# Altium schematic sheet Dimmer.SchDoc
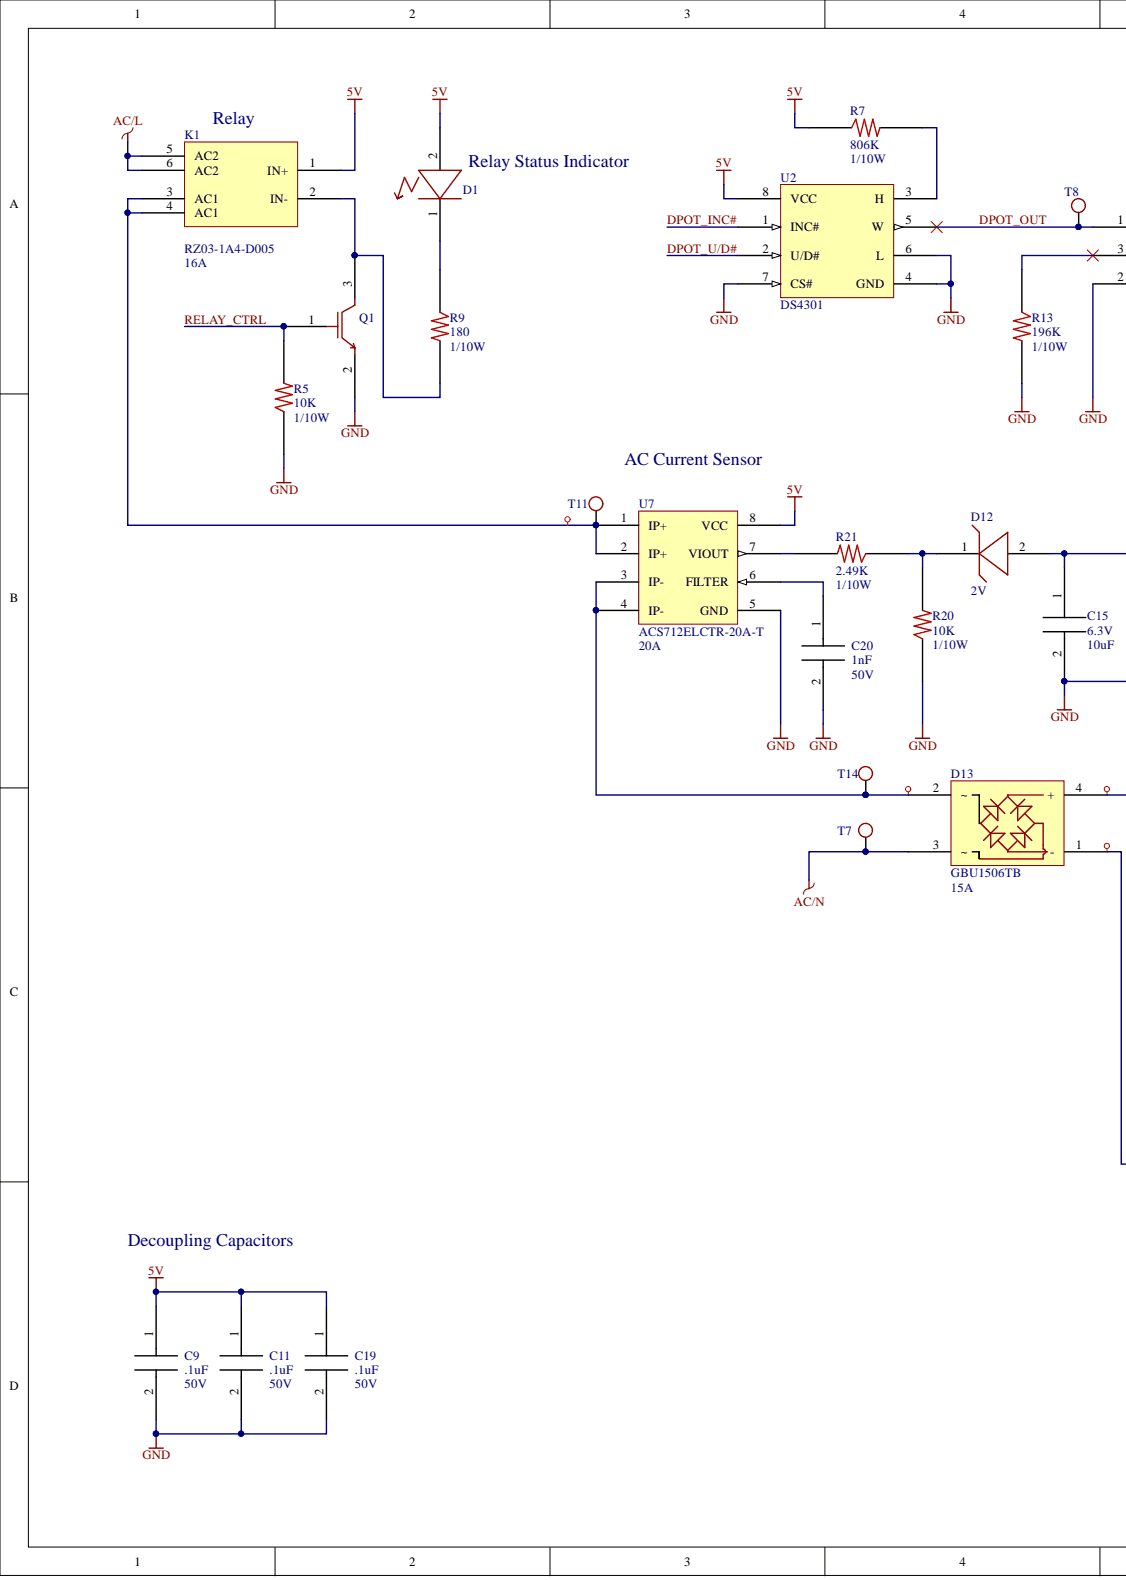
[diagram p0: Dimmer.SchDoc, left]
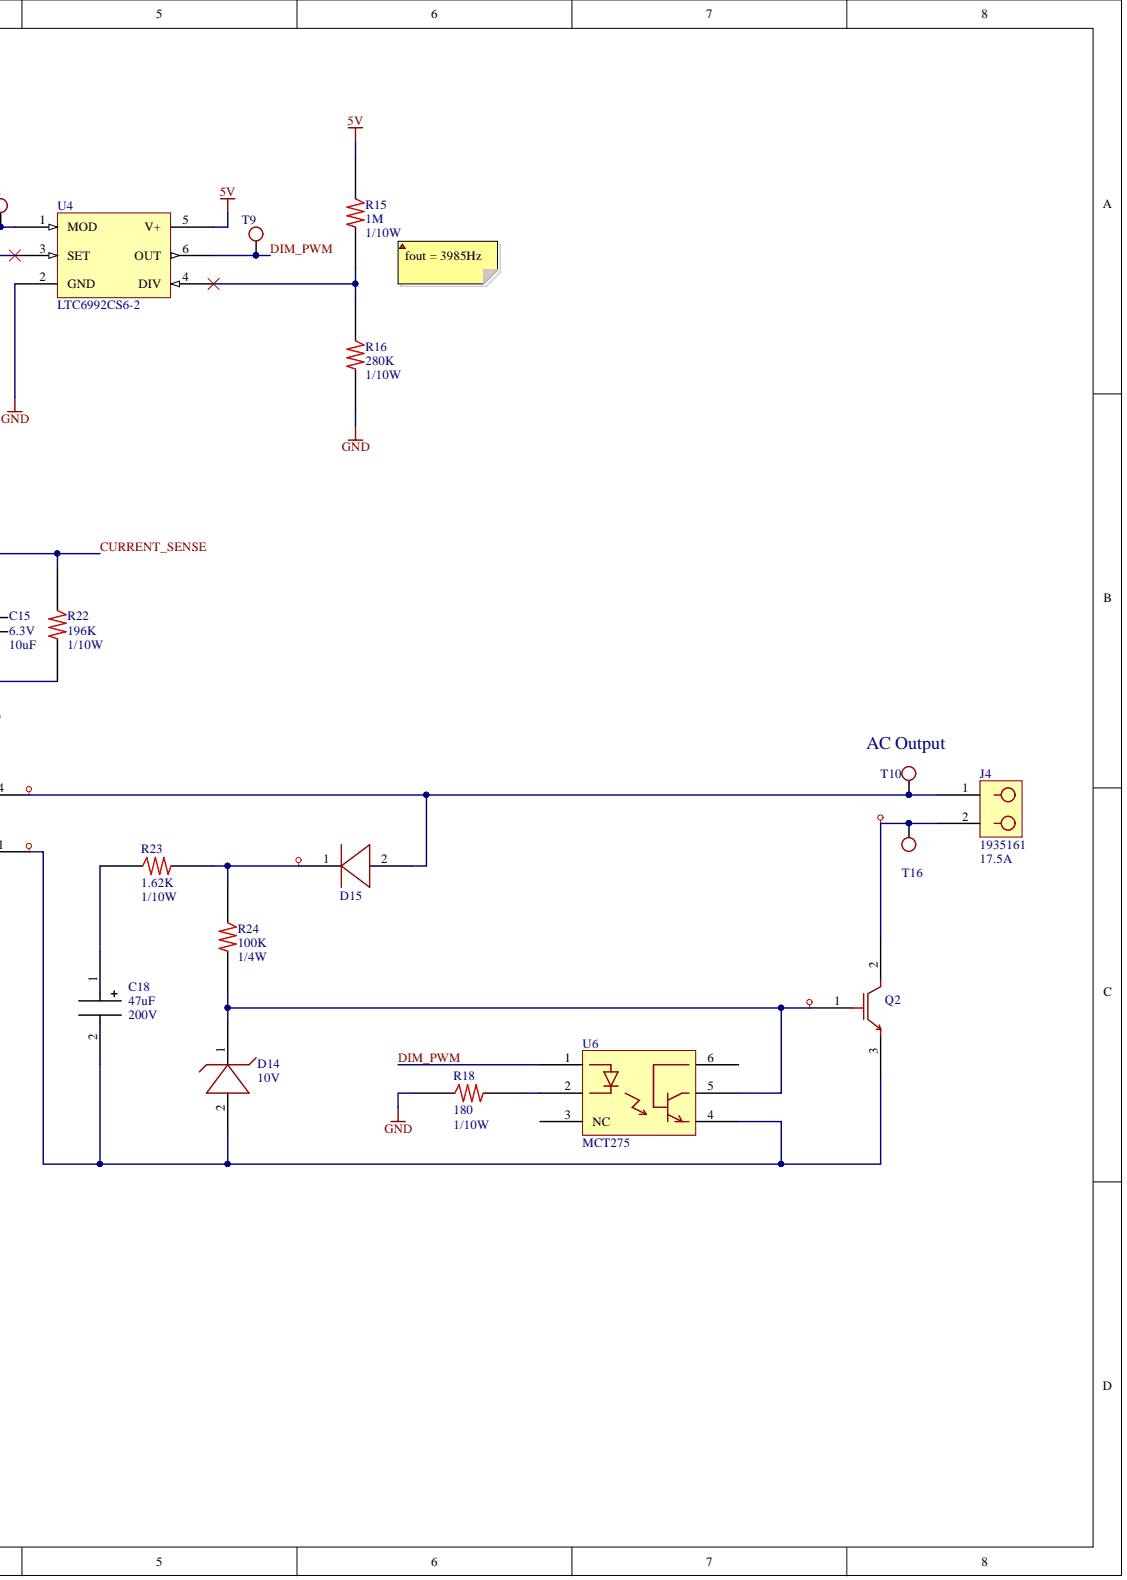
[diagram p1: Dimmer.SchDoc, right]

## Parts
C9  value .1uF  Rating: 50V  [0603]  (hidden comment: C_CAP_0603)
C11  value .1uF  Rating: 50V  [0603]  (hidden comment: C_CAP_0603)
C15  value 10uF  Rating: 6.3V  [0603]  (hidden comment: C_CAP_0603)
C18  value 47uF  Rating: 200V  [CAP12.5X20]  (hidden comment: CAP)
C19  value .1uF  Rating: 50V  [0603]  (hidden comment: C_CAP_0603)
C20  value 1nF  Rating: 50V  [0603]  (hidden comment: C_CAP_0603)
D1  D_LED_LED5MM  [LED5MM]  (value not drawn)
D12  value 2V  [SOD123]  (hidden comment: D_ZENER_SOD123)
D13  PartNumber: GBU1506TB  Rating: 15A  [GBU]  (hidden comment: D_RECTBRIDGE_GBU1506TB)
D14  value 10V  [SOD323]  (hidden comment: D_ZENER_SOD323)
D15  D_DIODE_SOD123  [SOD123]  (value not drawn)
J4  Rating: 17.5A  [1935161]  (hidden comment: J_2POSTERM_1935161)
K1  Rating: 16A  [RZ03-1A4-D005]  (hidden comment: K_RELAY-RZ03-1A4_RZ03-1A4-D005)
Q1  Q_NFET_SOT23  [SOT23]  (value not drawn)
Q2  Q_NFET_TO252  [TO252]  (value not drawn)
R5  value 10K  Rating: 1/10W  [0603]  (hidden comment: R_RES_0603)
R7  value 806K  Rating: 1/10W  [0603]  (hidden comment: R_RES_0603)
R9  value 180  Rating: 1/10W  [0603]  (hidden comment: R_RES_0603)
R13  value 196K  Rating: 1/10W  [0603]  (hidden comment: R_RES_0603)
R15  value 1M  Rating: 1/10W  [0603]  (hidden comment: R_RES_0603)
R16  value 280K  Rating: 1/10W  [0603]  (hidden comment: R_RES_0603)
R18  value 180  Rating: 1/10W  [0603]  (hidden comment: R_RES_0603)
R20  value 10K  Rating: 1/10W  [0603]  (hidden comment: R_RES_0603)
R21  value 2.49K  [0603]  (hidden comment: R_RES_0603)
R22  value 196K  Rating: 1/10W  [0603]  (hidden comment: R_RES_0603)
R23  value 1.62K  Rating: 1/10W  [0603]  (hidden comment: R_RES_0603)
R24  value 100K  Rating: 1/4W  [1206]  (hidden comment: R_RES_1206)
T7  TESTPOINT  [TESTPOINT2.5MM]  (value not drawn)
T8  TESTPOINT  [TESTPOINT1MM]  (value not drawn)
T9  TESTPOINT  [TESTPOINT1MM]  (value not drawn)
T10  TESTPOINT  [TESTPOINT2.5MM]  (value not drawn)
T11  TESTPOINT  [TESTPOINT2.5MM]  (value not drawn)
T14  TESTPOINT  [TESTPOINT2.5MM]  (value not drawn)
T16  TESTPOINT  [TESTPOINT2.5MM]  (value not drawn)
U2  PartNumber: DS4301  [MSOP8]  (hidden comment: U_DS4301_MSOP8)
U4  PartNumber: LTC6992CS6-2  [SOT23-6]  (hidden comment: U_LTC6992CS6-2_SOT23-6)
U6  PartNumber: MCT275  [DIP6]  (hidden comment: U_MCT275_DIP6)
U7  PartNumber: ACS712ELCTR-20A-T  Rating: 20A  [SOIC8]  (hidden comment: U_ACS712_SOIC8)

## Nets
5V: C9.1 C11.1 C19.1 D1.2 K1.1(IN+) R7.1 R15.1 U2.8(VCC) U4.5(V+) U7.8(VCC)
AC/L: K1.5(AC2) K1.6(AC2)
AC/N: D13.3(~) T7.1
GND: C9.2 C11.2 C15.2 C19.2 C20.2 Q1.2 R5.1 R13.2 R16.2 R18.1 R20.1 R22.2 U2.4(GND) U2.6(L) U2.7(CS#) U4.2(GND) U7.5(GND)
CURRENT_SENSE: C15.1 D12.2 R22.1
DIM_PWM: T9.1 U4.6(OUT) U6.1
DPOT_INC#: U2.1(INC#)
DPOT_OUT: T8.1 U2.5(W) U4.1(MOD)
DPOT_U/D#: U2.2(U/D#)
RELAY_CTRL: Q1.1 R5.2
NetC18_1: C18.1 R23.1
NetC18_2: C18.2 D13.1(-) D14.2 Q2.3 U6.4
NetC20_1: C20.1 U7.6(FILTER)
NetD1_1: D1.1 R9.1
NetD12_1: D12.1 R20.2 R21.1
NetD13_2: D13.2(~) T14.1 U7.3(IP-) U7.4(IP-)
NetD13_4: D13.4(+) D15.2 J4.1 T10.1
NetD14_1: D14.1 Q2.1 R24.2 U6.5
NetD15_1: D15.1 R23.2 R24.1
NetJ4_2: J4.2 Q2.2 T16.1
NetK1_2: K1.2(IN-) Q1.3 R9.2
NetK1_3: K1.3(AC1) K1.4(AC1) T11.1 U7.1(IP+) U7.2(IP+)
NetR7_2: R7.2 U2.3(H)
NetR13_1: R13.1 U4.3(SET)
NetR15_2: R15.2 R16.1 U4.4(DIV)
NetR18_2: R18.2 U6.2
NetR21_2: R21.2 U7.7(VIOUT)

Not connected: U6 3(NC) 6

## Library data of the parts
C9 C11 C19 = C_CAP_0603: Manufacturer: KEMET; PartNumber: C0603C104K5RACAUTO; Component Kind: Standard; Library Name: SeniorProject.SchLib
C15 = C_CAP_0603: Manufacturer: Taiyo Yuden; PartNumber: JMK107ABJ106MA-T; Component Kind: Standard; Library Name: SeniorProject.SchLib
C18 = C_CAP-P_CAP12.5X20: Manufacturer: Nichicon; PartNumber: UVR2D470MHD1TO; Component Kind: Standard; Library Name: SeniorProject.SchLib
C20 = C_CAP_0603: Manufacturer: AVX Corporation; PartNumber: 06035C102KAT2A; Component Kind: Standard; Library Name: SeniorProject.SchLib
D12 = D_ZENER_SOD123: Manufacturer: Diodes Incorporated; PartNumber: BZT52C2V0-7-F; Rating: 500mW; Component Kind: Standard; Library Name: SeniorProject.SchLib
D13 = D_RECTBRIDGE_GBU1506TB: Manufacturer: SMC Diode Solutions; Component Kind: Standard; Library Name: SeniorProject.SchLib
D14 = D_ZENER_SOD323: Manufacturer: On Semiconductor; PartNumber: MM3Z10VT1G; Rating: 300mW; Component Kind: Standard; Library Name: SeniorProject.SchLib
D15 = D_DIODE_SOD123: Manufacturer: Nexperia USA Inc; PartNumber: BAS16GWJ; Rating: 215mA; Component Kind: Standard; Library Name: SeniorProject.SchLib
J4 = J_2POSTERM_1935161: Manufacturer: Phoenix Contact; Component Kind: Standard; PartNumber: 1935161; Library Name: SeniorProject.SchLib
K1 = K_RELAY-RZ03-1A4_RZ03-1A4-D005: Manufacturer: TE Connectivity / Potter and Brumfield; Component Kind: Standard; PartNumber: RZ03-1A4-D005; Library Name: SeniorProject.SchLib
Q1 = Q_NFET_SOT23: Manufacturer: On Semiconductor; PartNumber: BSS138; Rating: 220mA; Component Kind: Standard; Library Name: SeniorProject.SchLib
Q2 = Q_NFET_TO252: Manufacturer: Infineon Technologies; PartNumber: IPD50R280CEAUMA1; Rating: 18.1A; Component Kind: Standard; Library Name: SeniorProject.SchLib
R5 R20 = R_RES_0603: Manufacturer: Yageo; PartNumber: RC0603JR-0710KL; Component Kind: Standard; Library Name: SeniorProject.SchLib
R7 = R_RES_0603: Manufacturer: Yageo; PartNumber: RC0603FR-07806KL; Component Kind: Standard; Library Name: SeniorProject.SchLib
R9 R18 = R_RES_0603: Manufacturer: Yageo; PartNumber: RC0603JR-07180RL; Component Kind: Standard; Library Name: SeniorProject.SchLib
R13 R22 = R_RES_0603: Manufacturer: Yageo; PartNumber: RC0603FR-07196KL; Component Kind: Standard; Library Name: SeniorProject.SchLib
R15 = R_RES_0603: Manufacturer: Yageo; PartNumber: RC0603FR-071ML; Component Kind: Standard; Library Name: SeniorProject.SchLib
R16 = R_RES_0603: Manufacturer: Yageo; PartNumber: RC0603FR-07280KL; Component Kind: Standard; Library Name: SeniorProject.SchLib
R21 = R_RES_0603: Manufacturer: Yageo; PartNumber: RC0603FR-072K49L; Rating: 1/10W; Component Kind: Standard; Library Name: SeniorProject.SchLib
R23 = R_RES_0603: Manufacturer: Yageo; PartNumber: RC0603FR-071K62L; Component Kind: Standard; Library Name: SeniorProject.SchLib
R24 = R_RES_1206: Manufacturer: Yageo; PartNumber: RC1206JR-07100KL; Component Kind: Standard; Library Name: SeniorProject.SchLib
T7 T10 T11 T14 T16 = T_TESTPOINT_TESTPOINT2.5MM: Value: 2.54mm; Component Kind: Standard; Library Name: SeniorProject.SchLib
T8 T9 = T_TESTPOINT_TESTPOINT1MM: Value: 1mm; Component Kind: Standard; Library Name: SeniorProject.SchLib
U2 = U_DS4301_MSOP8: Manufacturer: Maxim; Value: 200K; Component Kind: Standard; Library Name: SeniorProject.SchLib
U4 = U_LTC6992CS6-2_SOT23-6: Manufacturer: Linear; Component Kind: Standard; Library Name: SeniorProject.SchLib
U6 = U_MCT275_DIP6: Manufacturer: Vishay; Component Kind: Standard; Library Name: SeniorProject.SchLib
U7 = U_ACS712_SOIC8: Manufacturer: Allegro MicroSystems, LLC; Component Kind: Standard; Library Name: SeniorProject.SchLib
D1 = D_LED_LED5MM: Component Kind: Standard; Library Name: SeniorProject.SchLib
